# Altium schematic sheet STM32Core.SchDoc
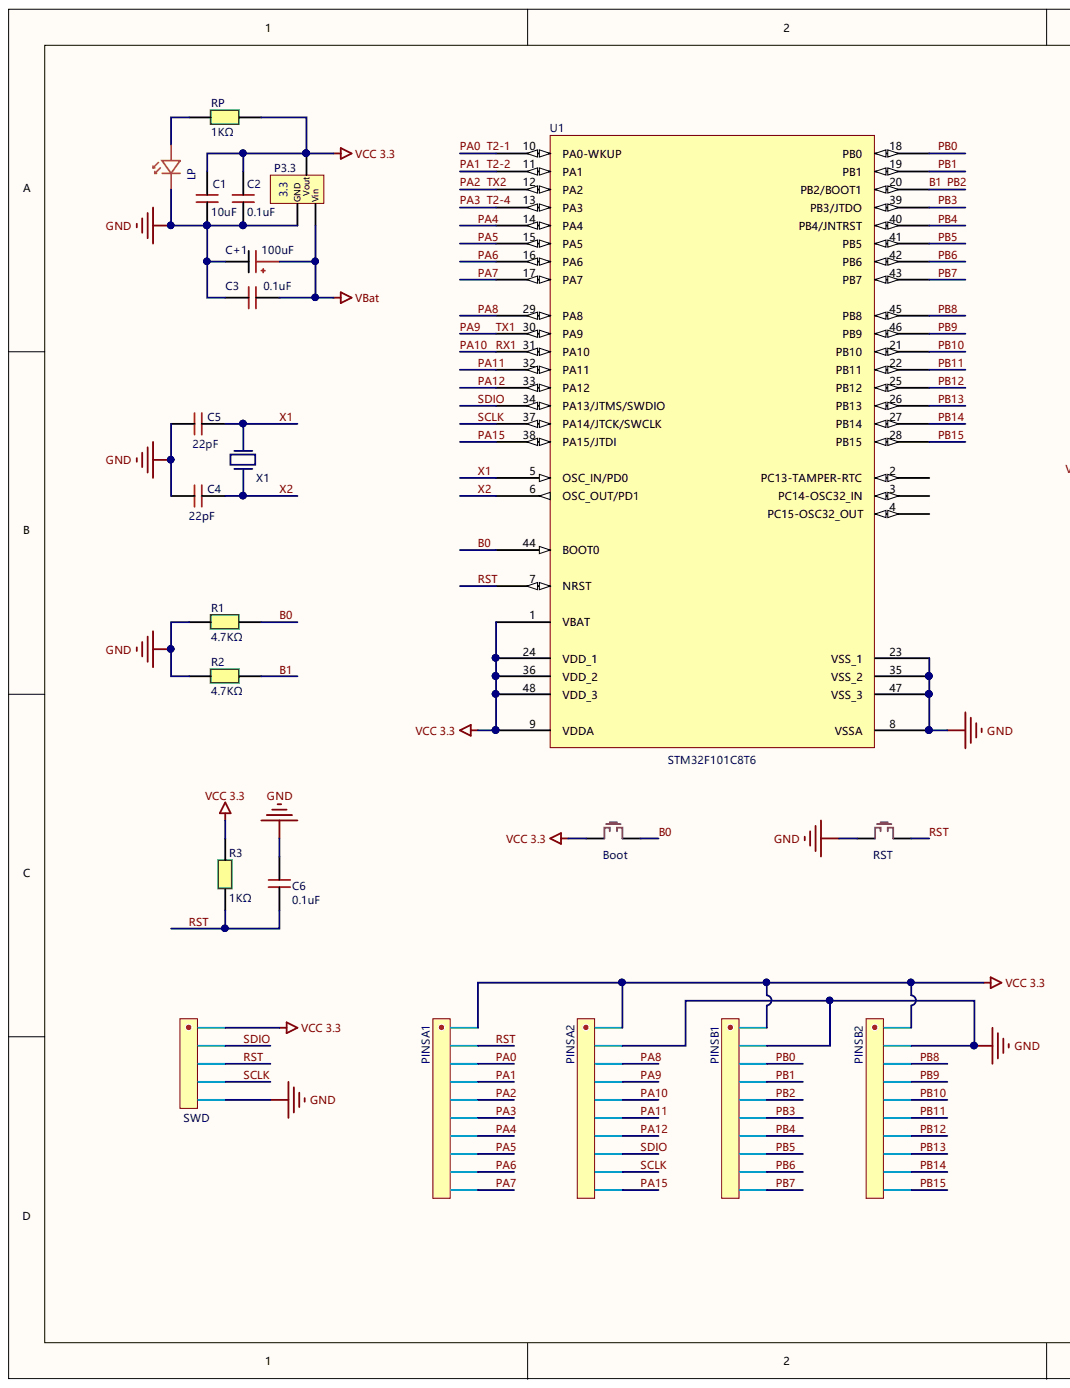
[diagram p0: STM32Core.SchDoc, left]
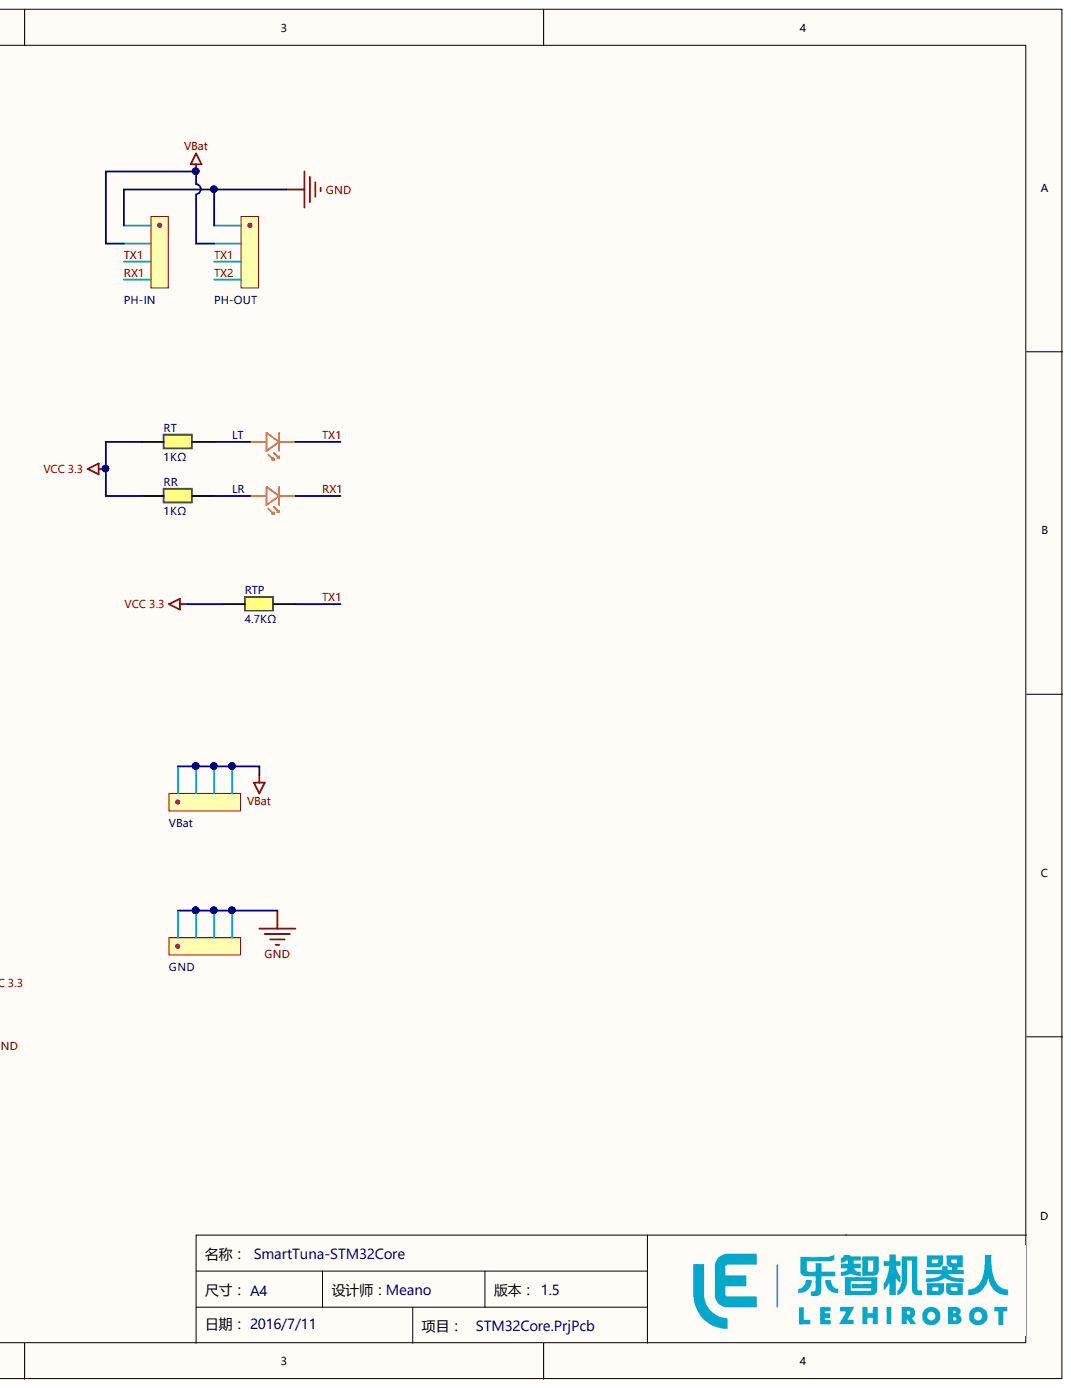
[diagram p1: STM32Core.SchDoc, right]

## Parts
Boot  Key  [KEY]  (value not drawn)
C1  value 10uF  [Cap - 0805]  (hidden comment: 电容)
C2  value 0.1uF  [Cap - 0805]  (hidden comment: Cap)
C3  value 0.1uF  [Cap - 0805]  (hidden comment: Cap)
C4  value 22pF  [Cap - 0805]  (hidden comment: Cap)
C5  value 22pF  [Cap - 0805]  (hidden comment: Cap)
C6  value 0.1uF  [Cap - 0805]  (hidden comment: Cap)
C+1  value 100uF  [CAP + - 7343]  (hidden comment: CapPlus)
GND  PINS4  [PINS4-1.27mm]  (value not drawn)
LP  LED Light  [LED - 0805]  (value not drawn)
LR  LED Light  [LED - 0805]  (value not drawn)
LT  LED Light  [LED - 0805]  (value not drawn)
P3.3  LDO电源芯片  [ASM1117]  (value not drawn)
PH-IN  PH2.0-4P  [PH2.0-4P]  (value not drawn)
PH-OUT  PH2.0-4P  [PH2.0-4P]  (value not drawn)
PINSA1  PINS10  [PINS10-1.27mm]  (value not drawn)
PINSA2  PINS10  [PINS10-1.27mm]  (value not drawn)
PINSB1  PINS10  [PINS10-1.27mm]  (value not drawn)
PINSB2  PINS10  [PINS10-1.27mm]  (value not drawn)
R1  value 4.7KΩ  [Res - 0805]  (hidden comment: Res)
R2  value 4.7KΩ  [Res - 0805]  (hidden comment: Res)
R3  value 1KΩ  [Res - 0805]  (hidden comment: Res)
RP  value 1KΩ  [Res - 0805]  (hidden comment: Res)
RR  value 1KΩ  [Res - 0805]  (hidden comment: Res)
RST  Key  [KEY]  (value not drawn)
RT  value 1KΩ  [Res - 0805]  (hidden comment: Res)
RTP  value 4.7KΩ  [Res - 0805]  (hidden comment: Res)
SWD  PH2.0-5P  [PH2.0-5P]  (value not drawn)
U1  STM32F101C8T6  [LQFP48_N]
VBat  PINS4  [PINS4-1.27mm]  (value not drawn)
X1  XTAL  [XTAL SMD]  (value not drawn)

## Nets
GND: C1.1 C2.1 C3.2 C4.1 C5.1 C6.1 C+1.2 GND.1 GND.2 GND.3 GND.4 LP.2 P3.3.1 PH-IN.1 PH-OUT.1 PINSA2.2 PINSB1.2 PINSB2.2 R1.1 R2.1 RST.2 SWD.5 U1.8(VSSA) U1.23(VSS_1) U1.35(VSS_2) U1.47(VSS_3)
VBAT: C3.1 C+1.1 P3.3.3 PH-IN.2 PH-OUT.2 VBat.1 VBat.2 VBat.3 VBat.4
VCC 3.3: Boot.2 C1.2 C2.2 P3.3.2 PINSA1.1 PINSA2.1 PINSB1.1 PINSB2.1 R3.2 RP.2 RR.1 RT.1 RTP.1 SWD.1 U1.1(VBAT) U1.9(VDDA) U1.24(VDD_1) U1.36(VDD_2) U1.48(VDD_3)
B0: Boot.1 R1.2 U1.44(BOOT0)
B1: PINSB1.5 R2.2 U1.20(PB2/BOOT1)
PA0: PINSA1.3 U1.10(PA0-WKUP)
PA1: PINSA1.4 U1.11(PA1)
PA2: PH-OUT.4 PINSA1.5 U1.12(PA2)
PA3: PINSA1.6 U1.13(PA3)
PA4: PINSA1.7 U1.14(PA4)
PA5: PINSA1.8 U1.15(PA5)
PA6: PINSA1.9 U1.16(PA6)
PA7: PINSA1.10 U1.17(PA7)
PA8: PINSA2.3 U1.29(PA8)
PA9: LT.2 PH-IN.3 PH-OUT.3 PINSA2.4 RTP.2 U1.30(PA9)
PA10: LR.2 PH-IN.4 PINSA2.5 U1.31(PA10)
PA11: PINSA2.6 U1.32(PA11)
PA12: PINSA2.7 U1.33(PA12)
PA15: PINSA2.10 U1.38(PA15/JTDI)
PB0: PINSB1.3 U1.18(PB0)
PB1: PINSB1.4 U1.19(PB1)
PB3: PINSB1.6 U1.39(PB3/JTDO)
PB4: PINSB1.7 U1.40(PB4/JNTRST)
PB5: PINSB1.8 U1.41(PB5)
PB6: PINSB1.9 U1.42(PB6)
PB7: PINSB1.10 U1.43(PB7)
PB8: PINSB2.3 U1.45(PB8)
PB9: PINSB2.4 U1.46(PB9)
PB10: PINSB2.5 U1.21(PB10)
PB11: PINSB2.6 U1.22(PB11)
PB12: PINSB2.7 U1.25(PB12)
PB13: PINSB2.8 U1.26(PB13)
PB14: PINSB2.9 U1.27(PB14)
PB15: PINSB2.10 U1.28(PB15)
RST: C6.2 PINSA1.2 R3.1 RST.1 SWD.3 U1.7(NRST)
SCLK: PINSA2.9 SWD.4 U1.37(PA14/JTCK/SWCLK)
SDIO: PINSA2.8 SWD.2 U1.34(PA13/JTMS/SWDIO)
X1: C5.2 U1.5(OSC_IN/PD0) X1.2
X2: C4.2 U1.6(OSC_OUT/PD1) X1.1
NetLP_1: LP.1 RP.1
NetLR_1: LR.1 RR.2
NetLT_1: LT.1 RT.2

Not connected: U1 2(PC13-TAMPER-RTC) 3(PC14-OSC32_IN) 4(PC15-OSC32_OUT)

## Library data of the parts
Boot RST = Key: Key2; Component Kind: Standard; Library Name: SmartTuna-ESchLib.SchLib
C1 = Cap: Component Kind: Standard; Description: 电容; Library Name: SmartTuna-ESchLib.SchLib
C2-C6 = Cap: Cap Normal; Component Kind: Standard
  C4 C5: Library Name: ATmega8.SchLib
  C2 C3 C6: Library Name: SmartTuna-ESchLib.SchLib
C+1 = Cap+: Component Kind: Standard; Description: Cap+; Library Name: SmartTuna-ESchLib.SchLib
LP LR LT = LED: Component Kind: Standard; Library Name: SmartTuna-ESchLib.SchLib
P3.3 = ASM1117-3.3: Component Kind: Standard; Description: ASM1117-3.3; Library Name: SmartTuna-ESchLib.SchLib
U1 = STM32F101C8T6: STM32 ARM-based 32-bit MCU with 64 Kbytes Flash, 48-pin LQFP, Industrial Temperature; Component Kind: Standard; ComponentLink1Description: Manufacturer Link; ComponentLink1URL: www.st.com/stm32; ComponentLink2Description: Datasheet; ComponentLink2URL: http://www.st.com/stonline/products/literature/ds/13586.pdf; DatasheetVersion: rev6, Mar-2008; Library Name: ST Microcontroller 32-Bit STM32.SchLib; PackageDescription: 48-Pin Low-Profile Quad Flat Pack, 7 x 7 mm Body, 0.5 mm Pitch (LQFP48); PackageReference: LQFP48; PackageVersion: Mar-2008; Published: 30-May-2008; Publisher: Altium Limited
GND VBat = PINS4: Component Kind: Standard; Description: PINS4; Library Name: SmartTuna-ESchLib.SchLib
PH-IN PH-OUT = PH2.0-4P: Component Kind: Standard; Description: PH2.0-4P; Library Name: SmartTuna-ESchLib.SchLib
PINSA1 PINSA2 PINSB1 PINSB2 = PINS10: Component Kind: Standard; Description: PINS10; Library Name: SmartTuna-ESchLib.SchLib
R1-R3 RP RR RT RTP = Res: Component Kind: Standard; Description: Res; Library Name: SmartTuna-ESchLib.SchLib
SWD = PH2.0-5P: Component Kind: Standard; Description: PH2.0-5P; Library Name: SmartTuna-ESchLib.SchLib
X1 = XTAL: Component Kind: Standard; Description: XTAL; Library Name: SmartTuna-ESchLib.SchLib
